# Altium schematic sheet AVI_Board.SchDoc
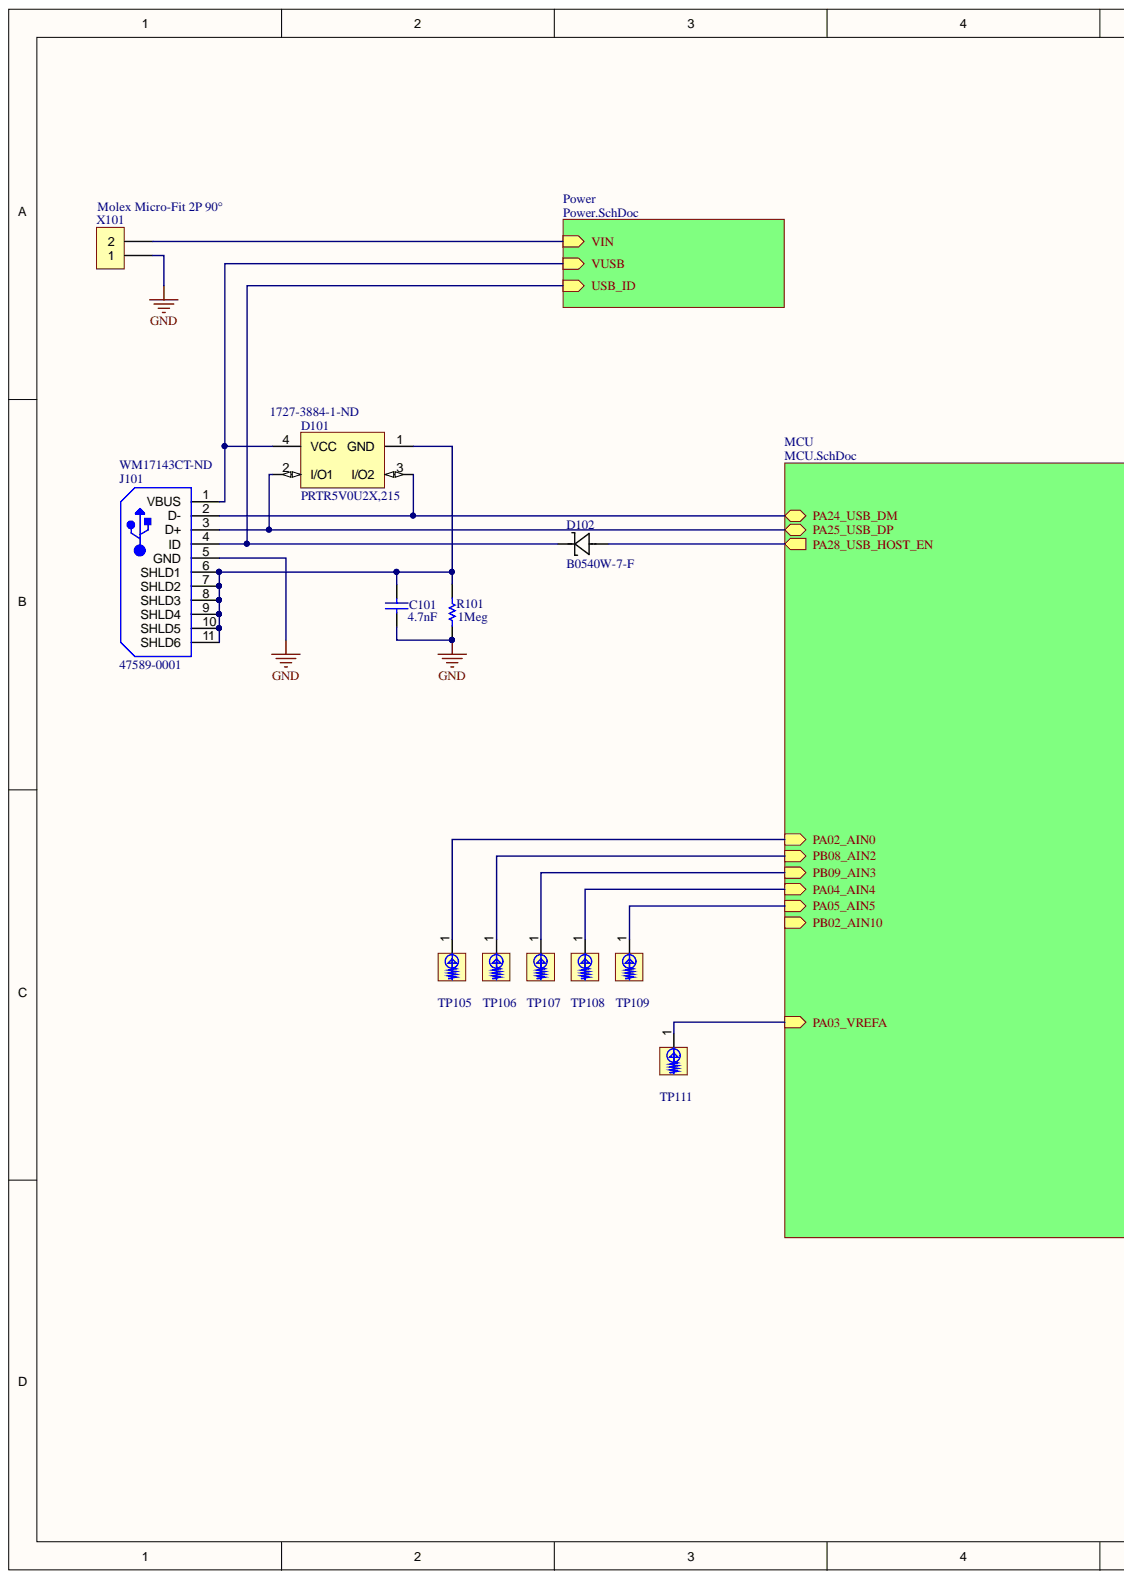
[diagram p0: AVI_Board.SchDoc, left]
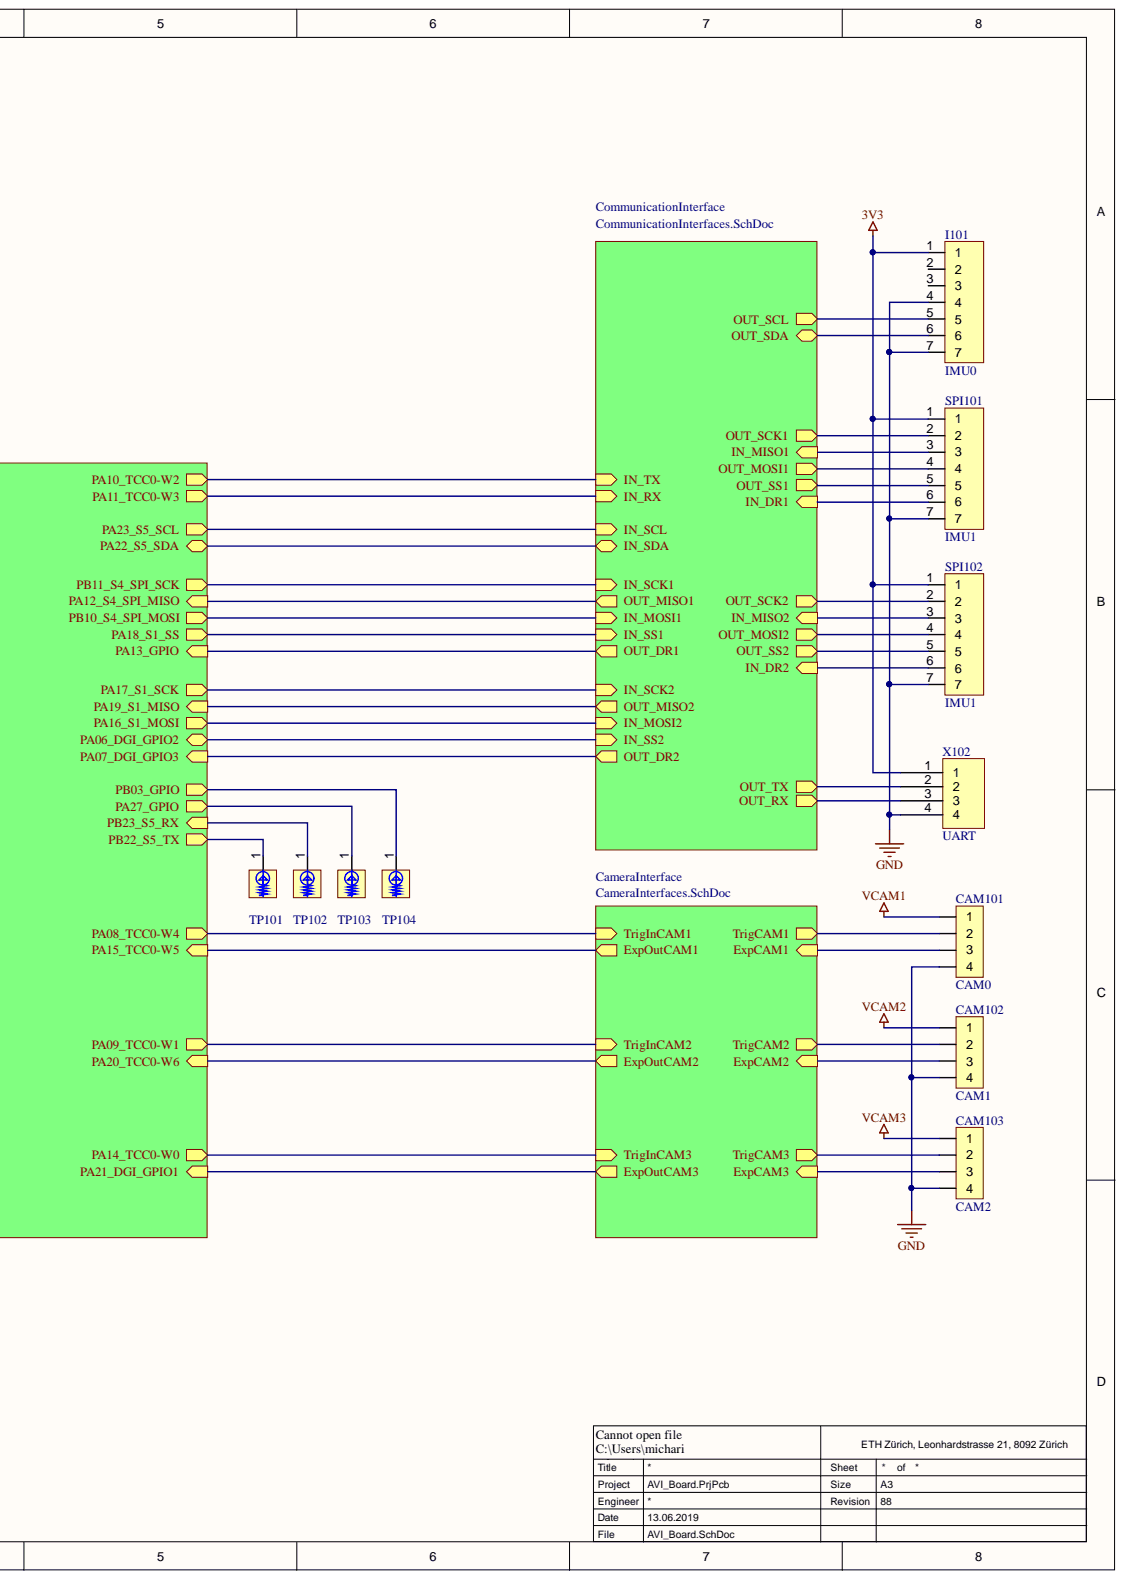
[diagram p1: AVI_Board.SchDoc, right]

## Parts
C101  value 4.7nF  [CAPC0603(1608)100_L]  (hidden comment: CAP 4.7nF 100V 0603(1608))
CAM101  CAM0  [MolexMicro-Fit 4P]
CAM102  CAM1  [MolexMicro-Fit 4P]
CAM103  CAM2  [MolexMicro-Fit 4P]
D101  PRTR5V0U2X,215  Supplier Part Number 1: 1727-3884-1-ND  [NXP-SOT143B-4_V]
D102  B0540W-7-F  [SOD123]
I101  IMU0  [DF13_CONN_7P_90_SMT]
J101  47589-0001  Supplier Part Number 1: WM17143CT-ND  [MOLX-47589-0001_V]
R101  value 1Meg  [RESC0603(1608)_N]  (hidden comment: 1M 5% 0603(1608))
SPI101  IMU1  [DF13_CONN_7P_90_SMT]
SPI102  IMU1  [DF13_CONN_7P_90_SMT]
TP101  TP  [TP-1.5mm]
TP102  TP  [TP-1.5mm]
TP103  TP  [TP-1.5mm]
TP104  TP  [TP-1.5mm]
TP105  TP  [TP-1.5mm]
TP106  TP  [TP-1.5mm]
TP107  TP  [TP-1.5mm]
TP108  TP  [TP-1.5mm]
TP109  TP  [TP-1.5mm]
TP111  TP  [TP-1.5mm]
X101  Molex Micro-Fit 2P 90°  [MolexMicro-Fit 2P 90°]
X102  UART  [DF13_CONN_4P_90_SMT]

## Nets
3V3: I101.1 SPI101.1 SPI102.1 X102.1
GND: C101.1 CAM101.4 CAM102.4 CAM103.4 I101.4 I101.7 J101.5(GND) R101.1 SPI101.7 SPI102.7 X101.1 X102.4
VCAM1: CAM101.1
VCAM2: CAM102.1
VCAM3: CAM103.1
NetC101_2: C101.2 D101.1(GND) J101.6(SHLD1) J101.7(SHLD2) J101.8(SHLD3) J101.9(SHLD4) J101.10(SHLD5) J101.11(SHLD6) R101.2
NetCAM101_2: CAM101.2
NetCAM101_3: CAM101.3
NetCAM102_2: CAM102.2
NetCAM102_3: CAM102.3
NetCAM103_2: CAM103.2
NetCAM103_3: CAM103.3
NetD101_2: D101.2(I/O1) J101.3(D+)
NetD101_3: D101.3(I/O2) J101.2(D-)
NetD101_4: D101.4(VCC) J101.1(VBUS)
NetD102_1: D102.1 J101.4(ID)
NetD102_2: D102.2
NetI101_2: I101.2
NetI101_3: I101.3
NetI101_5: I101.5
NetI101_6: I101.6
NetSPI101_2: SPI101.2
NetSPI101_3: SPI101.3
NetSPI101_4: SPI101.4
NetSPI101_5: SPI101.5
NetSPI101_6: SPI101.6
NetSPI102_2: SPI102.2
NetSPI102_3: SPI102.3
NetSPI102_4: SPI102.4
NetSPI102_5: SPI102.5
NetSPI102_6: SPI102.6
NetTP101_1: TP101.1
NetTP102_1: TP102.1
NetTP103_1: TP103.1
NetTP104_1: TP104.1
NetTP105_1: TP105.1
NetTP106_1: TP106.1
NetTP107_1: TP107.1
NetTP108_1: TP108.1
NetTP109_1: TP109.1
NetTP111_1: TP111.1
NetX101_2: X101.2
NetX102_2: X102.2
NetX102_3: X102.3
ExpOutCAM1:
ExpOutCAM2:
ExpOutCAM3:
IN_MOSI1:
IN_MOSI2:
IN_RX:
IN_SCK1:
IN_SCK2:
IN_SCL:
IN_SDA:
IN_SS1:
IN_SS2:
IN_TX:
OUT_DR1:
OUT_DR2:
OUT_MISO1:
OUT_MISO2:
PB02_AIN10:
TrigInCAM1:
TrigInCAM2:
TrigInCAM3:

## Library data of the parts
C101 = CMP-1035-02208-1: CAP 4.7nF 100V ±10% 0603 (1608 Metric) Thickness 1mm SMD; Case-EIA: 0603; Case-Metric: 1608; Tolerance: Â±10%; Component Kind: Standard; Library Name: Altium Content Vault; Max Thickness: 1 mm; Rated Voltage: 100 V; Supplier 1: Digi-Key; Supplier Part Number 1: 399-14206-1-ND; Technology: SMT
CAM101-CAM103 = Molex Micro-Fit 4P 90°: PARTNR: 043045-0401; Component Kind: Standard; Description: Molex Micro-Fit 4P 90°; MANUFACTURER: Molex; Supplier 1: Digi-Key; Supplier Part Number 1: WM1814-ND; Library Name: AVI_ArduinoShield Rev 2.SCHLIB
D101 = CMP-2000-05285-1: Ultra Low Capacitance Double Rail-to-Rail ESD Protection Diode, 5.5 V, 1 pF, -40 to 85 degC, 4-Pin SOT143B, RoHS, Tape and Reel; Manufacturer: NXP Semiconductors; Capacitance Value: 16pF; Configuration: Quad; Direction Type: Uni-Directional; ESD Protection Voltage: 8KV@ContactDisc; Leakage Current: 0.1uA; Number of Elements: 1; Product Depth (mm): 1.4mm; Product Height (mm): 1mm; Product Length (mm): 3mm; Rad Hardened: No; Reverse Breakdown Voltage: 6V; Reverse Stand-off Voltage: 5.5V; Suppressor Type: DiodeArrays; Component Kind: Standard; Datasheet URL: https://datasheet.ciiva.com/4479/prtr5v0u2x-102853-4479461.pdf; Datasheet Version: Rev. 02, 01/2008; Leakage Current (Max): 0.1uA; Library Name: Altium Content Vault; Manufacturer URL: http://www.nxp.com/; Mounting: SurfaceMount; Mounting Style: SurfaceMount; Operating Temperature Classification: Industrial; Operating Temperature Max Deg. C: 85C; Operating Temperature Min Deg. C: -40C; Operating Temp Range: -40Cto85C; Package / Case: SOT; Package Type: SOT; Packaging: TapeandReel; Pin Count: 3+Tab; Polarity: Uni-Directional; Supplier 1: Digi-Key; Working Voltage: 5.5V; Working Voltage (Max): 5.5V
D102 = B0540W-7-F: Shottky Diode 60V500mA; Built_By: EMA_Anthony; Type: DEV; Vendor: Diodes Inc; Component Kind: Standard; Manufacturer_Part_Number: B0540W-7-F; RefDes: RefDes; Supplier 1: Digi-Key; Supplier Part Number 1: B0540W-FDICT-ND; Value: Value; Copyright: Copyright (C) 2016 Accelerated Designs. All rights reserved; Library Name: AVI_Board.SCHLIB
I101 SPI101 SPI102 = DF13_CONN_7P_90_SMD: Single Row Pin Header (SMD); MANUFACTURER: HIROSE; PARTNR: DF13A-7P-1.25H(50); Component Kind: Standard; Supplier 1: Digi-Key; Supplier Part Number 1: H3385-ND; Library Name: AVI_ArduinoShield Rev 2.SCHLIB
J101 = CMP-2000-05610-1: Right Angle Female Type AB Micro USB Connector, 30 V, 1.8 A, -30 to 85 degC, RoHS, Tape and Reel; Brand: Molex; Connector Type: MicroUSBTypeABreceptacle; Current Rating: 1.8A; Number of Contacts: 5Contact; Number of Ports: 1Port; Voltage Rating: 30VAC; : 0475890001; Color: Black; Component Kind: Standard; Contact Material: CopperAlloy; Contact Plating: Gold; Datasheet URL: http://www.molex.com/webdocs/datasheets/pdf/en-us/0475890001_IO_CONNECTORS.pdf; Datasheet Version: 12/2016; Gender: Female; Library Name: Altium Content Vault; Manufacturer: Molex; Manufacturer URL: http://www.molex.com/; Mounting Angle: Right; Mounting Technology: SurfaceMount; Operating Temperature Range: -20Cto+85C; Package Description: Micro USB Connector, Pitch 0.65 mm, 5 Positions, Body 7.5 x 5.6 mm; Package Reference: 47589-0001; Package URL: http://www.molex.com/pdm_docs/sd/475890001_sd.pdf; Packaging: Reel; Product: MicroUSBTypeABConnectors; Product Category: USBConnectors; RoHS: True; Series: 47589; Standard: USB; Supplier 1: Digi-Key; Termination Style: SMD/SMT
R101 = CMP-1012-00146-1: 1M 0.1W 5% 0603 (1608 Metric)  SMD; Case-EIA: 0603; Case-Metric: 1608; Component Kind: Standard; Library Name: Altium Content Vault; Power: 0.1 W; Supplier 1: Digi-Key; Supplier Part Number 1: P1.0MGCT-ND; Technology: SMT; Tolerance: 5 %
TP101-TP109 TP111 = Testpoint - ICT: testpoint; MANUFACTURER: none; Component Kind: Standard; Library Name: Connectors.SchLib; PARTNR: none
X101 = Molex Micro-Fit 2P 90°: Molex Micro-Fit 2P 0436500201; Component Kind: Standard; Library Name: Connectors.SchLib; Supplier 1: Digi-Key; Supplier Part Number 1: WM1865-ND
X102 = DF13_CONN_4P_90_SMD: Single Row Pin Header (SMD); MANUFACTURER: HIROSE; PARTNR: DF13A-4P-1.25H(50); Component Kind: Standard; Library Name: Connectors.SchLib; Supplier 1: Digi-Key; Supplier Part Number 1: H3382-ND
